# Altium schematic sheet p2_mcu.SchDoc(p2_mcu)
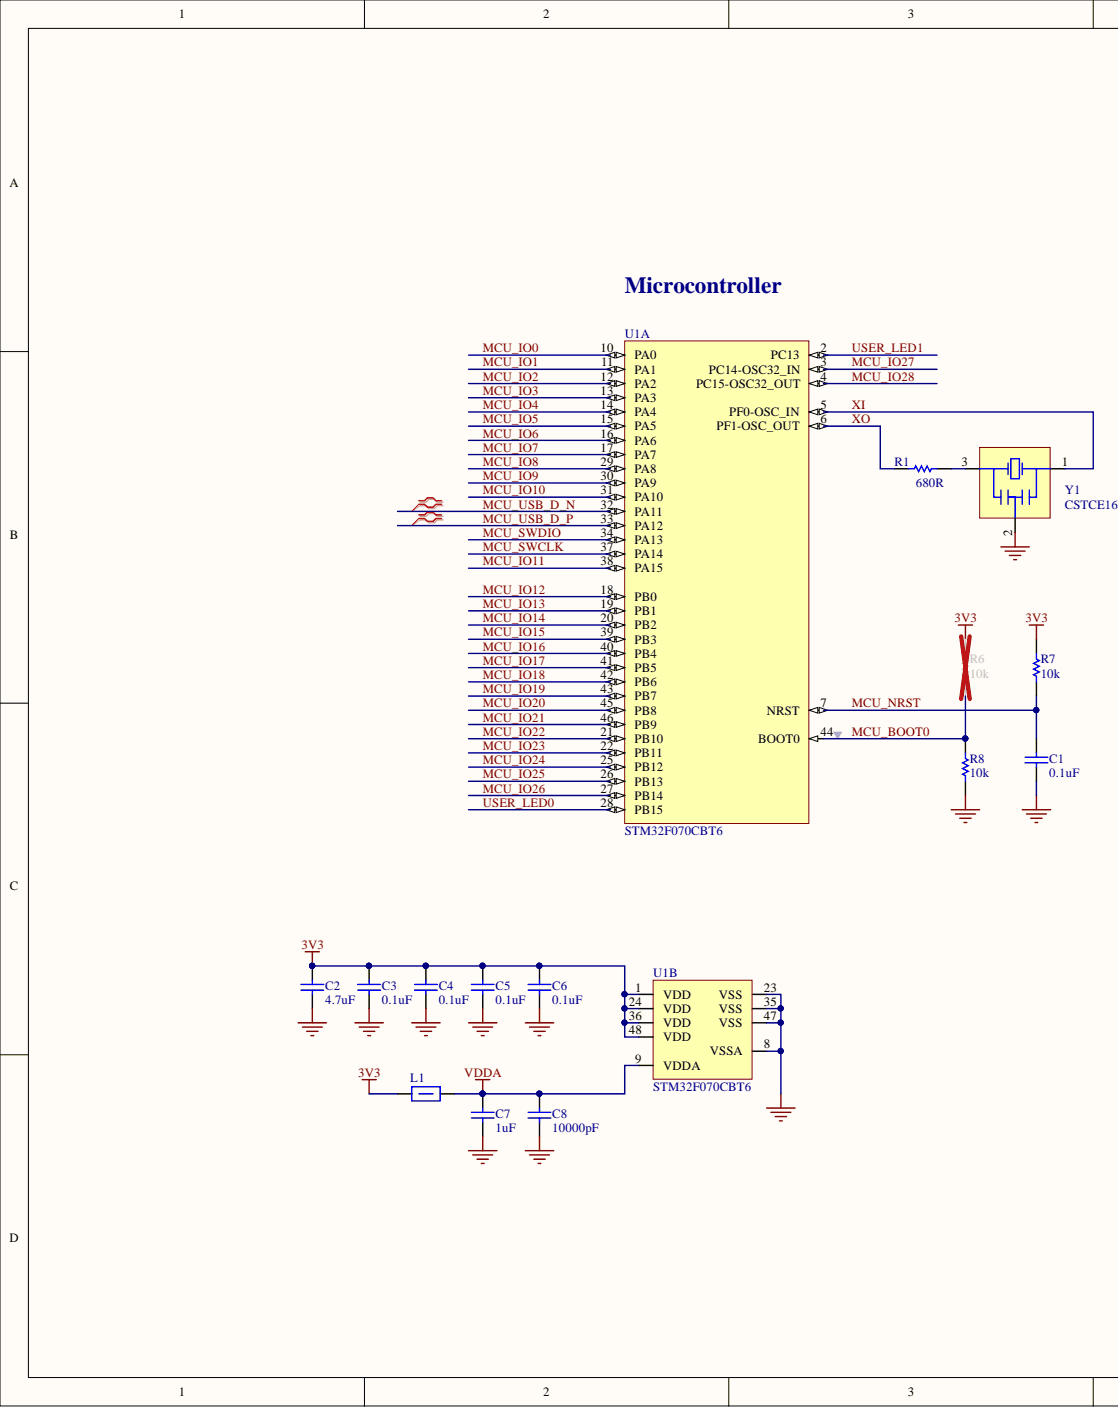
[diagram p0: p2_mcu.SchDoc, left]
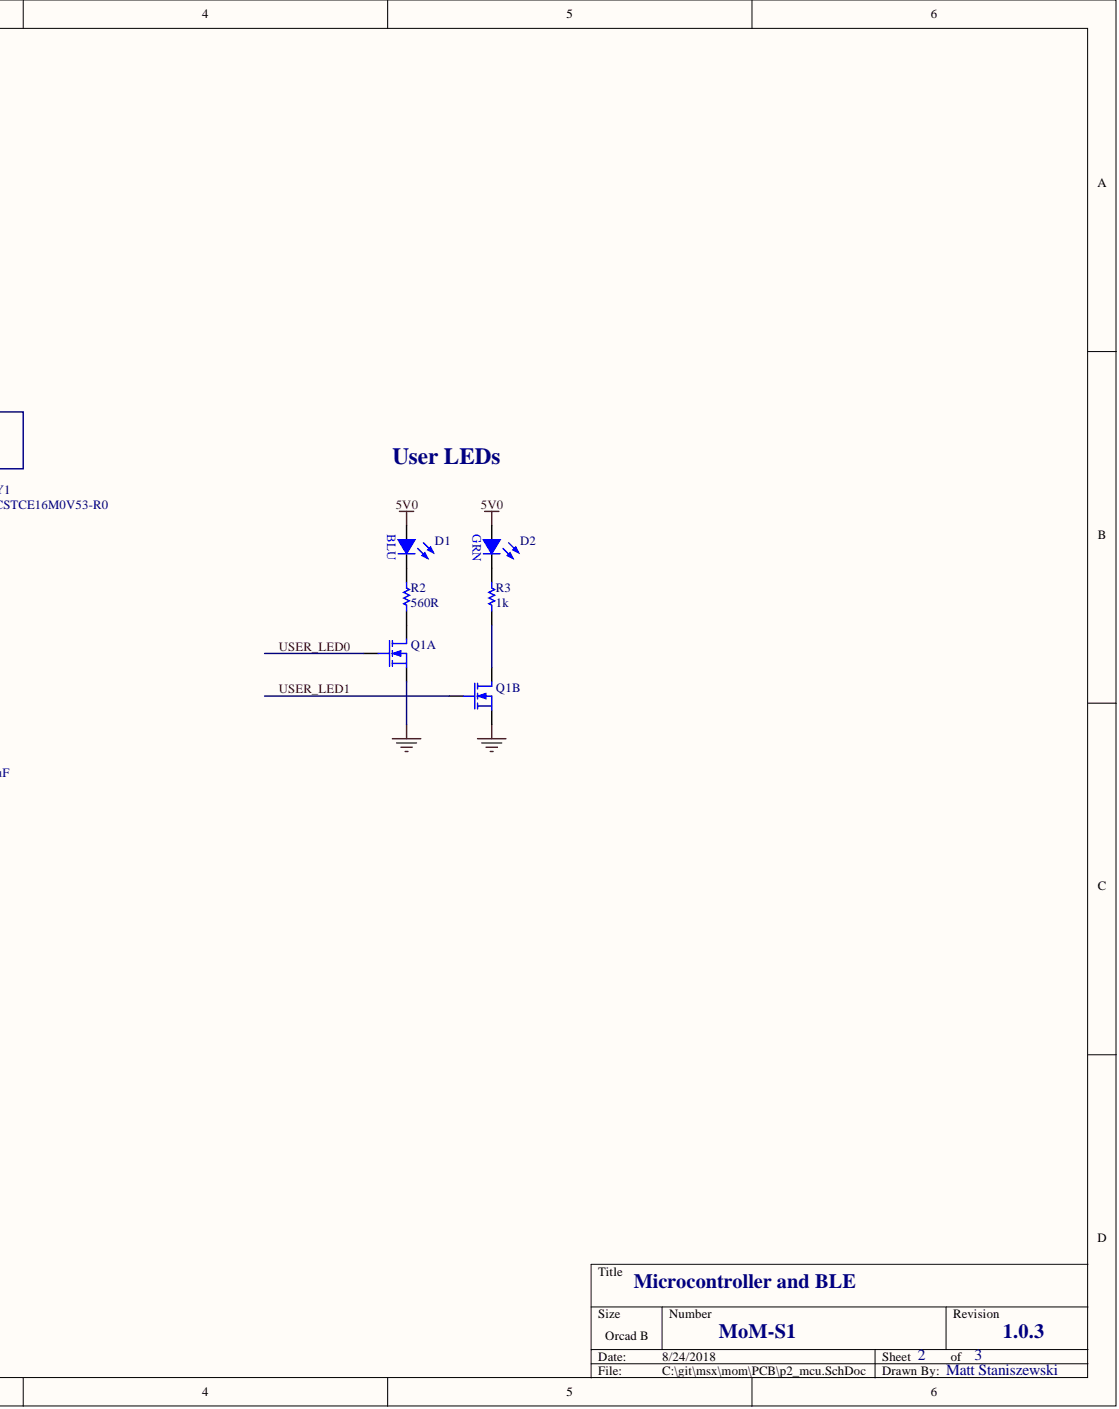
[diagram p1: p2_mcu.SchDoc, right]

## Parts
C1  0.1uF  [C0201]
C2  4.7uF  [C0402]
C3  0.1uF  [C0201]
C4  0.1uF  [C0201]
C5  0.1uF  [C0201]
C6  0.1uF  [C0201]
C7  1uF  [C0402]
C8  10000pF  [C0201]
D1  5988091107F  [LED0603]  (value not drawn)
D2  5988070107F  [LED0603]  (value not drawn)
L1  BLM15AG601SN1D  [L0402]  (value not drawn)
Q1  PMDXB600UNE  [NXP_DFN1010B-6]  (value not drawn)  (parts A,B)
R1  680R  [R0201]
R2  560R  [R0201]
R3  1k  [R0201]
R6  10k  [R0201]
R7  10k  [R0201]
R8  10k  [R0201]
U1  STM32F070CBT6  [STM_LQFP48]  (parts A,B)
Y1  CSTCE16M0V53-R0  [MUR_CSTCE_V]

## Nets
3V3: C2.2 C3.2 C4.2 C5.2 C6.2 L1.1 R6.2 R7.2 U1.1(VDD) U1.24(VDD) U1.36(VDD) U1.48(VDD)
5V0: D1.2 D2.2
GND: C1.1 C2.1 C3.1 C4.1 C5.1 C6.1 C7.1 C8.1 Q1.1 Q1.4 R8.1 U1.8(VSSA) U1.23(VSS) U1.35(VSS) U1.47(VSS) Y1.2
VDDA: C7.2 C8.2 L1.2 U1.9(VDDA)
MCU_BOOT0: R6.1 R8.2 U1.44(BOOT0)
MCU_IO0: U1.10(PA0)
MCU_IO1: U1.11(PA1)
MCU_IO2: U1.12(PA2)
MCU_IO3: U1.13(PA3)
MCU_IO4: U1.14(PA4)
MCU_IO5: U1.15(PA5)
MCU_IO6: U1.16(PA6)
MCU_IO7: U1.17(PA7)
MCU_IO8: U1.29(PA8)
MCU_IO9: U1.30(PA9)
MCU_IO10: U1.31(PA10)
MCU_IO11: U1.38(PA15)
MCU_IO12: U1.18(PB0)
MCU_IO13: U1.19(PB1)
MCU_IO14: U1.20(PB2)
MCU_IO15: U1.39(PB3)
MCU_IO16: U1.40(PB4)
MCU_IO17: U1.41(PB5)
MCU_IO18: U1.42(PB6)
MCU_IO19: U1.43(PB7)
MCU_IO20: U1.45(PB8)
MCU_IO21: U1.46(PB9)
MCU_IO22: U1.21(PB10)
MCU_IO23: U1.22(PB11)
MCU_IO24: U1.25(PB12)
MCU_IO25: U1.26(PB13)
MCU_IO26: U1.27(PB14)
MCU_IO27: U1.3(PC14-OSC32_IN)
MCU_IO28: U1.4(PC15-OSC32_OUT)
MCU_NRST: C1.2 R7.1 U1.7(NRST)
MCU_SWCLK: U1.37(PA14)
MCU_SWDIO: U1.34(PA13)
MCU_USB_D_N: U1.32(PA11)
MCU_USB_D_P: U1.33(PA12)
USER_LED0: Q1.2 U1.28(PB15)
USER_LED1: Q1.5 U1.2(PC13)
XI: U1.5(PF0-OSC_IN) Y1.1
XO: R1.1 U1.6(PF1-OSC_OUT)
NetD1_1: D1.1 R2.2
NetD2_1: D2.1 R3.2
NetQ1_3: Q1.3 R3.1
NetQ1_6: Q1.6 R2.1
NetR1_2: R1.2 Y1.3

## Library data of the parts
C1 C3-C6 = 0.1uF 16V 0201: CAP CER 0.1UF 16V X5R 0201; Manufacturer Part Number: GRM033R61C104ME84D; Manufacturer: Murata; Tolerance: 20%; Capacitance: 1E-07f; Width: 0.3mm; Weight: 1.2E-05oz; Voltage Rating (DC): 16V; Voltage Rating: 16V; Thickness: 0.013inch; Termination: SMD/SMT; Supplier Part Number 1: 490-9986-1-ND; Supplier 1: Digi-Key; Stock 1: 660567; Pricing 1: 1=0.1, 10=0.029, 100=0.013, 500=0.0093, 1000=0.0073, 2500=0.0067, 5000=0.0061 (USD); Packaging: Tape and Reel; Package Quantity: 15000; Min Operating Temperature: -55°C; Max Operating Temperature: 85°C; Library Name: Capacitors.SchLib; Length: 0.024inch; Height: 0.3mm; Dielectric: X5R; ComponentLink1URL: http://www.mouser.com/ds/2/281/c02e-2905.pdf; ComponentLink1Description: http://www.mouser.com/ds/2/281/c02e-2905.pdf; Component Kind: Standard; Case Code (Metric): 0603; Case Code (Imperial): 0201; Case/Package: 0201
C2 = 4.7uF 16V 0402: CAP CER 4.7UF 16V X5R 0402; Manufacturer Part Number: CL05A475MO5NUNC; Manufacturer: Samsung; Tolerance: 20%; Width: 0.02inch; Voltage Rating: 16V; Thickness: 0.022inch; Supplier Part Number 1: 1276-6836-1-ND; Supplier 1: Digi-Key; Stock 1: 282710; Pricing 1: 1=0.36, 10=0.248, 100=0.1485, 500=0.1114, 1000=0.0941, 2500=0.0891, 5000=0.0842 (USD); Packaging: Tape and Reel; Min Operating Temperature: -55°C; Max Operating Temperature: 85°C; Library Name: Capacitors.SchLib; Length: 0.039inch; Dielectric: X5R; Component Kind: Standard; Case Code (Metric): 1005; Case Code (Imperial): 0402; Case/Package: 0402; Capacitance: 4.7uF
C7 = 1uF 25V 0402: CAP CER 1UF 25V X5R 0402; Manufacturer Part Number: TMK105BJ105MV-F; Manufacturer: Taiyo Yuden; Tolerance: 20%; Width: 0.02inch; Voltage Rating (DC): 25V; Voltage Rating: 25V; Thickness: 0.022inch; Termination: SMD/SMT; Supplier Part Number 1: 587-3245-1-ND; Supplier 1: Digi-Key; Stock 1: 113342; Radiation Hardening: No; Pricing 1: 1=0.2, 10=0.14, 100=0.066, 500=0.0463, 1000=0.0383, 2500=0.0357, 5000=0.0326 (USD); Packaging: Tape and Reel; Package Quantity: 1; Mount: Surface Mount; Min Operating Temperature: -55°C; Max Operating Temperature: 85°C; Library Name: Capacitors.SchLib; Length: 1mm; Height: 0.5mm; Halogen Free: Halogen Free; Dielectric: X5R; Depth: 0.5mm; Composition: Ceramic; ComponentLink4URL: https://datasheet.ciiva.com/30154/128tmk105bj105mv-f-30154639.pdf; ComponentLink4Description: https://datasheet.ciiva.com/30154/128tmk105bj105mv-f-30154639.pdf; ComponentLink3URL: https://datasheet.ciiva.com/13404/mlcc-all-e-541559-13404206.pdf; ComponentLink3Description: https://datasheet.ciiva.com/13404/mlcc-all-e-541559-13404206.pdf; ComponentLink2URL: https://datasheet.ciiva.com/15922/tmk105bj105mv-f-pdf-15922317.pdf; ComponentLink2Description: https://datasheet.ciiva.com/15922/tmk105bj105mv-f-pdf-15922317.pdf; ComponentLink1URL: https://datasheet.ciiva.com/11596/tmk105bj105mv-f-ss-11596466.pdf; ComponentLink1Description: https://datasheet.ciiva.com/11596/tmk105bj105mv-f-ss-11596466.pdf; Component Kind: Standard; Case Code (Metric): 1005; Case Code (Imperial): 0402; Case/Package: 0402; Capacitance: 1uF
C8 = 10000pF 25V 0201: CAP CER 10000PF 25V X5R 0201; Manufacturer Part Number: GRM033R61E103MA12D; Manufacturer: Murata; Tolerance: 20%; Capacitance: 10nF; Width: 0.012inch; Voltage Rating (DC): 25V; Voltage Rating: 25V; Thickness: 0.013inch; Supplier Part Number 1: 490-9992-1-ND; Supplier 1: Digi-Key; Stock 1: 20535; Pricing 1: 1=0.1, 10=0.024, 100=0.0106, 500=0.00756, 1000=0.00595, 2500=0.00541, 5000=0.00497 (USD); Packaging: Tape and Reel; Mount: Surface Mount; Min Operating Temperature: -55°C; Max Operating Temperature: 85°C; Library Name: Capacitors.SchLib; Length: 0.024inch; Dielectric: X5R; ComponentLink1URL: http://search.murata.co.jp/Ceramy/image/img/A01X/partnumbering_e_01.pdf; ComponentLink1Description: http://search.murata.co.jp/Ceramy/image/img/A01X/partnumbering_e_01.pdf; Component Kind: Standard; Case Code (Metric): 0603; Case Code (Imperial): 0201; Case/Package: 0201
D1 = Blue 0603 LED: LED BLUE CLEAR 0603 SMD; Manufacturer Part Number: 598-8091-107F; Manufacturer: Dialight; Wavelength: 475nm; Viewing Angle: 140°; Test Current: 20mA; Power Dissipation: 70mW; Number of LEDs: 1; Luminous Intensity: 140mcd; Lens Style: Clear,Diffused,Colorless,Rectangular; Lens Colour: Clear,Colorless; Forward Voltage: 3.2V; Dominant Wavelength: 470nm; Width: 0.8mm; Supplier Part Number 1: 350-2037-1-ND; Supplier 1: Digi-Key; Stock 1: 42042; REACH SVHC: No SVHC; Radiation Hardening: No; Pricing 1: 1=0.75, 10=0.583, 25=0.4864, 100=0.4189, 250=0.374, 500=0.3216, 1000=0.2483 (USD); Packaging: Tape and Reel; Package Quantity: 4000; Number of Pins: 2; Number of Elements: 1; Mount: Surface Mount; Min Operating Temperature: -30°C; Max Operating Temperature: 100°C; Library Name: Diodes.SchLib; Lens Transparency: Clear; Length: 1.6mm; Lead Free: Lead Free; Illumination Colour: Blue; Height: 0.7mm; Forward Current: 20mA; Depth: 0.8mm; ComponentLink9URL: https://datasheet.ciiva.com/16400/smt-selector-guide-16400478.pdf; ComponentLink9Description: https://datasheet.ciiva.com/16400/smt-selector-guide-16400478.pdf; ComponentLink8URL: https://datasheet.ciiva.com/14603/70081992-14603963.pdf; ComponentLink8Description: https://datasheet.ciiva.com/14603/70081992-14603963.pdf; ComponentLink7URL: https://datasheet.ciiva.com/7970/25658-7970182.pdf; ComponentLink7Description: https://datasheet.ciiva.com/7970/25658-7970182.pdf; ComponentLink6URL: https://datasheet.ciiva.com/2111/598-series-0603-pkg-2111558.pdf; ComponentLink6Description: https://datasheet.ciiva.com/2111/598-series-0603-pkg-2111558.pdf; ComponentLink5URL: https://datasheet.ciiva.com/30240/mdei5980603-30240533.pdf; ComponentLink5Description: https://datasheet.ciiva.com/30240/mdei5980603-30240533.pdf; ComponentLink4URL: https://datasheet.ciiva.com/15965/mdei5980603-15965445.pdf; ComponentLink4Description: https://datasheet.ciiva.com/15965/mdei5980603-15965445.pdf; ComponentLink3URL: https://datasheet.ciiva.com/2111/598-series-0603-pkg-2111557.pdf; ComponentLink3Description: https://datasheet.ciiva.com/2111/598-series-0603-pkg-2111557.pdf; ComponentLink2URL: https://datasheet.ciiva.com/2111/598-series-0603-pkg-2111556.pdf; ComponentLink2Description: https://datasheet.ciiva.com/2111/598-series-0603-pkg-2111556.pdf; ComponentLink1URL: https://datasheet.ciiva.com/15118/getdatasheetpartid-194139-15118048.pdf; ComponentLink1Description: https://datasheet.ciiva.com/15118/getdatasheetpartid-194139-15118048.pdf; Component Kind: Standard; Colour: Blue; Case Code (Metric): 1608; Case Code (Imperial): 0603; Case/Package: 0603
D2 = Green 0603 LED: LED GREEN CLEAR 0603 SMD; Manufacturer Part Number: 598-8070-107F; Manufacturer: Dialight; Wavelength: 570nm; Viewing Angle: 140°; Test Current: 20mA; Power Dissipation: 60mW; Number of LEDs: 1; Luminous Intensity: 20mcd; Lens Style: Clear,Diffused,Colorless,Rectangular; Forward Voltage: 2V; Dominant Wavelength: 568nm; Width: 0.8mm; Supplier Part Number 1: 350-2035-1-ND; Supplier 1: Digi-Key; Stock 1: 147636; REACH SVHC: No SVHC; Radiation Hardening: No; Pricing 1: 1=0.45, 10=0.327, 25=0.2504, 100=0.1634, 250=0.1198, 500=0.1016, 1000=0.0835 (USD); Packaging: Tape and Reel; Package Quantity: 4000; Number of Pins: 2; Number of Elements: 1; Mount: Surface Mount; Min Operating Temperature: -30°C; Max Operating Temperature: 100°C; Library Name: Diodes.SchLib; Lens Colour: Clear; Length: 1.6mm; Lead Free: Lead Free; Illumination Colour: Green; Height: 0.7mm; Forward Current: 20mA; Depth: 0.8mm; ComponentLink13URL: https://datasheet.ciiva.com/16400/smt-selector-guide-16400463.pdf; ComponentLink13Description: https://datasheet.ciiva.com/16400/smt-selector-guide-16400463.pdf; ComponentLink12URL: https://datasheet.ciiva.com/22749/1484480-22749228.pdf; ComponentLink12Description: https://datasheet.ciiva.com/22749/1484480-22749228.pdf; ComponentLink11URL: https://datasheet.ciiva.com/15117/getdatasheetpartid-146438-15117836.pdf; ComponentLink11Description: https://datasheet.ciiva.com/15117/getdatasheetpartid-146438-15117836.pdf; ComponentLink10URL: https://datasheet.ciiva.com/30188/mdei5980603-30188139.pdf; ComponentLink10Description: https://datasheet.ciiva.com/30188/mdei5980603-30188139.pdf; ComponentLink9URL: https://datasheet.ciiva.com/15965/mdei5980603-15965419.pdf; ComponentLink9Description: https://datasheet.ciiva.com/15965/mdei5980603-15965419.pdf; ComponentLink8URL: https://datasheet.ciiva.com/14605/70081990-14605530.pdf; ComponentLink8Description: https://datasheet.ciiva.com/14605/70081990-14605530.pdf; ComponentLink7URL: https://datasheet.ciiva.com/2110/598-series-0603-pkg-2110167.pdf; ComponentLink7Description: https://datasheet.ciiva.com/2110/598-series-0603-pkg-2110167.pdf; ComponentLink6URL: https://datasheet.ciiva.com/2110/598-series-0603-pkg-2110166.pdf; ComponentLink6Description: https://datasheet.ciiva.com/2110/598-series-0603-pkg-2110166.pdf; ComponentLink5URL: https://datasheet.ciiva.com/1243/1484480-1243894.pdf; ComponentLink5Description: https://datasheet.ciiva.com/1243/1484480-1243894.pdf; ComponentLink4URL: https://datasheet.ciiva.com/2110/598-series-0603-pkg-2110165.pdf; ComponentLink4Description: https://datasheet.ciiva.com/2110/598-series-0603-pkg-2110165.pdf; ComponentLink3URL: https://datasheet.ciiva.com/1243/1484480-1243893.pdf; ComponentLink3Description: https://datasheet.ciiva.com/1243/1484480-1243893.pdf; ComponentLink2URL: https://datasheet.ciiva.com/11774/0900766b80ae2975-11774257.pdf; ComponentLink2Description: https://datasheet.ciiva.com/11774/0900766b80ae2975-11774257.pdf; ComponentLink1URL: https://datasheet.ciiva.com/4688/598-selectorguide-18902-4688880.pdf; ComponentLink1Description: https://datasheet.ciiva.com/4688/598-selectorguide-18902-4688880.pdf; Component Kind: Standard; Colour: Green; Case Code (Metric): 1608; Case Code (Imperial): 0603; Case/Package: 0603
L1 = BLM15AG601SN1D: FERRITE BEAD 600 OHM 0402 1LN; Manufacturer: Murata; Tolerance: 25%; Test Frequency: 100MHz; Shielding: Unshielded; Number of Lines: 1; Max DC Current: 300mA; Material: Steel,Ferrite; Impedance: 600R; DC Resistance (DCR): 520mR; Width: 0.5mm; Termination: SMD/SMT; Supplier Part Number 1: 490-1006-1-ND; Supplier 1: Digi-Key; Stock 1: 1368913; REACH SVHC: No SVHC; Radiation Hardening: No; Pricing 1: 1=0.1, 10=0.069, 25=0.0628, 50=0.0564, 100=0.0414, 250=0.03008, 500=0.02758, 1000=0.02383, 5000=0.01881 (USD); Packaging: Tape and Reel; Package Quantity: 10000; Number of Pins: 2; Number of Circuits: 1; Min Operating Temperature: -55°C; Military Standard: Not; Max Operating Temperature: 125°C; Max Current Rating: 300mA; Manufacturer Part Number: BLM15AG601SN1D; Library Name: Inductors.SchLib; Length: 1mm; Lead Free: Lead Free; Height: 0.5mm; Frequency: 100MHz; Depth: 0.55mm; DC Current: 300mA; Current Rating: 300mA; Core Material: Ferrite; ComponentLink11URL: https://datasheet.ciiva.com/41449/001172163-da-01-en-drossel-0402-600r-1-0x0-5mm-10000st-41449450.pdf; ComponentLink11Description: https://datasheet.ciiva.com/41449/001172163-da-01-en-drossel-0402-600r-1-0x0-5mm-10000st-41449450.pdf; ComponentLink10URL: https://datasheet.ciiva.com/29347/442210-da-01-en-chip-drossel-0402-600r-300ma-1-0x0-5mm-29347879.pdf; ComponentLink10Description: https://datasheet.ciiva.com/29347/442210-da-01-en-chip-drossel-0402-600r-300ma-1-0x0-5mm-29347879.pdf; ComponentLink9URL: https://datasheet.ciiva.com/8084/l0110s0100blm15ag-sn-8084271.pdf; ComponentLink9Description: https://datasheet.ciiva.com/8084/l0110s0100blm15ag-sn-8084271.pdf; ComponentLink8URL: https://datasheet.ciiva.com/14122/blm-series-eng-tds-14122894.pdf; ComponentLink8Description: https://datasheet.ciiva.com/14122/blm-series-eng-tds-14122894.pdf; ComponentLink7URL: https://datasheet.ciiva.com/8510/l0110s0100blm15ag-sn-8510909.pdf; ComponentLink7Description: https://datasheet.ciiva.com/8510/l0110s0100blm15ag-sn-8510909.pdf; ComponentLink6URL: https://datasheet.ciiva.com/11799/0900766b811b5866-11799226.pdf; ComponentLink6Description: https://datasheet.ciiva.com/11799/0900766b811b5866-11799226.pdf; ComponentLink5URL: https://datasheet.ciiva.com/1466/5144-1466745.pdf; ComponentLink5Description: https://datasheet.ciiva.com/1466/5144-1466745.pdf; ComponentLink4URL: https://datasheet.ciiva.com/6212/l0110s0100blm15ag-sn-6212520.pdf; ComponentLink4Description: https://datasheet.ciiva.com/6212/l0110s0100blm15ag-sn-6212520.pdf; ComponentLink3URL: https://datasheet.ciiva.com/1466/5144-1466744.pdf; ComponentLink3Description: https://datasheet.ciiva.com/1466/5144-1466744.pdf; ComponentLink2URL: https://datasheet.ciiva.com/6212/l0110s0100blm15ag-sn-6212519.pdf; ComponentLink2Description: https://datasheet.ciiva.com/6212/l0110s0100blm15ag-sn-6212519.pdf; ComponentLink1URL: https://datasheet.ciiva.com/6212/l0110s0100blm15ag-sn-6212565.pdf; ComponentLink1Description: https://datasheet.ciiva.com/6212/l0110s0100blm15ag-sn-6212565.pdf; Component Kind: Standard; Case Code (Metric): 1005; Case Code (Imperial): 0402; Case/Package: 0402
Q1 = PMDXB600UNE: MOSFET 2N-CH 20V 0.6A 6DFN; Manufacturer: NXP Semiconductors; Threshold Voltage: 700mV; Rds On Max: 620mR; Max Power Dissipation: 265mW; Input Capacitance: 21.3pF; Continuous Drain Current (ID): 600mA; Supplier Part Number 1: 1727-1470-1-ND; Supplier 1: Digi-Key; Stock 1: 27879; REACH SVHC: No SVHC; Pricing 1: 1=0.65, 10=0.552, 100=0.4124, 500=0.324, 1000=0.2504 (USD); Packaging: Tape and Reel; Number of Pins: 6; Min Operating Temperature: -55°C; Max Operating Temperature: 150°C; Manufacturer Part Number: PMDXB600UNE; Library Name: ICs.SchLib; Drain to Source Voltage (Vdss): 20V; ComponentLink3URL: https://datasheet.ciiva.com/29589/pmdxb600une-29589933.pdf; ComponentLink3Description: https://datasheet.ciiva.com/29589/pmdxb600une-29589933.pdf; ComponentLink2URL: https://datasheet.ciiva.com/29589/pmdxb600une-29589932.pdf; ComponentLink2Description: https://datasheet.ciiva.com/29589/pmdxb600une-29589932.pdf; ComponentLink1URL: https://datasheet.ciiva.com/29589/pmdxb600une-29589931.pdf; ComponentLink1Description: https://datasheet.ciiva.com/29589/pmdxb600une-29589931.pdf; Component Kind: Standard; Case/Package: 600
R1 = 680R 0201: RES SMD 680 OHM 1% 1/20W 0201; Manufacturer Part Number: RC0201FR-07680RL; Manufacturer: Yageo; Voltage Rating: 25V; Temperature Coefficient: 200ppm/°C; Power Rating: 50mW; Width: 0.012inch; Tolerance: 1%; Termination: SMD/SMT; Supplier Part Number 1: YAG2769CT-ND; Supplier 1: Digi-Key; Stock 1: 13371; Resistance: 680R; REACH SVHC: No SVHC; Pricing 1: 1=0.1, 10=0.046, 100=0.0187, 1000=0.00841, 2500=0.0073, 5000=0.00603 (USD); Packaging: Tape and Reel; Number of Terminations: 2; Min Operating Temperature: -55°C; Military Standard: Not; Max Power Dissipation: 50mW; Max Operating Temperature: 125°C; Library Name: Resistors.SchLib; Length: 0.6mm; Lead Free: Lead Free; Height: 0.01inch; Depth: 0.3mm; Composition: Thick Film; ComponentLink1URL: https://datasheet.ciiva.com/7672/1563626-7672238.pdf; ComponentLink1Description: https://datasheet.ciiva.com/7672/1563626-7672238.pdf; Component Kind: Standard; Case Code (Metric): 0603; Case Code (Imperial): 0201; Case/Package: 0201
R2 = 560R 0201: RES SMD 560 OHM 1% 1/20W 0201; Manufacturer Part Number: ERJ-1GEF5600C; Manufacturer: Panasonic ECG; Voltage Rating (DC): 15V; Voltage Rating: 25V; Temperature Coefficient: 200ppm/°C; Power Rating: 50mW; Width: 0.3mm; Tolerance: 1%; Supplier Part Number 1: P560ABCT-ND; Supplier 1: Digi-Key; Stock 1: 11988; Resistance: 560R; Radiation Hardening: No; Pricing 1: 1=0.1, 10=0.059, 100=0.024, 1000=0.0108, 2500=0.0094, 5000=0.0077 (USD); Packaging: Tape and Reel; Package Quantity: 15000; Number of Terminations: 2; Mount: Surface Mount; Min Operating Temperature: -55°C; Military Standard: Not; Max Power Dissipation: 50mW; Max Operating Temperature: 125°C; Library Name: Resistors.SchLib; Length: 0.6mm; Lead Free: Lead Free; Height: 0.01inch; Depth: 0.3mm; Contact Plating: Tin; Composition: Thick Film; ComponentLink3URL: https://datasheet.ciiva.com/2440/erj-g-r-e-rev08-2440590.pdf; ComponentLink3Description: https://datasheet.ciiva.com/2440/erj-g-r-e-rev08-2440590.pdf; ComponentLink2URL: https://datasheet.ciiva.com/2440/erj-g-r-e-rev08-2440589.pdf; ComponentLink2Description: https://datasheet.ciiva.com/2440/erj-g-r-e-rev08-2440589.pdf; ComponentLink1URL: https://datasheet.ciiva.com/2440/erj-g-r-e-rev08-2440588.pdf; ComponentLink1Description: https://datasheet.ciiva.com/2440/erj-g-r-e-rev08-2440588.pdf; Component Kind: Standard; Case Code (Metric): 0603; Case Code (Imperial): 0201; Case/Package: 0201
R3 = 1k 0201: RES SMD 1K OHM 1% 1/20W 0201; Manufacturer Part Number: ERJ-1GEF1001C; Manufacturer: Panasonic ECG; Voltage Rating (DC): 15V; Voltage Rating: 25V; Temperature Coefficient: 200ppm/°C; Resistance: 1KR; Power Rating: 50mW; Width: 0.012inch; Tolerance: 1%; Termination: SMD/SMT; Supplier Part Number 1: P1.00KABCT-ND; Supplier 1: Digi-Key; Stock 1: 3138057; REACH SVHC: Unknown; Radiation Hardening: No; Pricing 1: 1=0.1, 10=0.059, 100=0.024, 1000=0.0108, 2500=0.0094, 5000=0.0077 (USD); Packaging: Tape and Reel; Package Quantity: 15000; Number of Terminations: 2; Mount: Surface Mount; Min Operating Temperature: -55°C; Military Standard: Not; Max Power Dissipation: 50mW; Max Operating Temperature: 125°C; Library Name: Resistors.SchLib; Length: 0.6mm; Lead Free: Lead Free; Height: 0.23mm; Depth: 0.3mm; Contact Plating: Tin; Composition: Thick Film; ComponentLink6URL: https://datasheet.ciiva.com/16782/70260683-16782463.pdf; ComponentLink6Description: https://datasheet.ciiva.com/16782/70260683-16782463.pdf; ComponentLink5URL: https://datasheet.ciiva.com/7942/1678796-7942463.pdf; ComponentLink5Description: https://datasheet.ciiva.com/7942/1678796-7942463.pdf; ComponentLink4URL: https://datasheet.ciiva.com/30155/aoa0000ce2-30155870.pdf; ComponentLink4Description: https://datasheet.ciiva.com/30155/aoa0000ce2-30155870.pdf; ComponentLink3URL: https://datasheet.ciiva.com/16199/erj-1gef1001c-16199565.pdf; ComponentLink3Description: https://datasheet.ciiva.com/16199/erj-1gef1001c-16199565.pdf; ComponentLink2URL: https://datasheet.ciiva.com/16763/70260683-16763516.pdf; ComponentLink2Description: https://datasheet.ciiva.com/16763/70260683-16763516.pdf; ComponentLink1URL: https://datasheet.ciiva.com/28532/1694929-28532042.pdf; ComponentLink1Description: https://datasheet.ciiva.com/28532/1694929-28532042.pdf; Component Kind: Standard; Case Code (Metric): 0603; Case Code (Imperial): 0201; Case/Package: 0201
R6-R8 = 10k 0201: RES SMD 10K OHM 1% 1/20W 0201; Manufacturer Part Number: ERJ-1GEF1002C; Manufacturer: Panasonic ECG; Voltage Rating (DC): 15V; Voltage Rating: 25V; Temperature Coefficient: 200ppm/°C; Resistance: 10KR; Power Rating: 50mW; Width: 0.012inch; Tolerance: 1%; Termination: SMD/SMT; Supplier Part Number 1: P10.0KABCT-ND; Supplier 1: Digi-Key; Stock 1: 4709989; REACH SVHC: Unknown; Radiation Hardening: No; Pricing 1: 1=0.1, 10=0.059, 100=0.024, 1000=0.0108, 2500=0.0094, 5000=0.0077 (USD); Packaging: Tape and Reel; Package Quantity: 15000; Number of Terminations: 2; Mount: Surface Mount; Min Operating Temperature: -55°C; Military Standard: Not; Max Power Dissipation: 50mW; Max Operating Temperature: 125°C; Library Name: Resistors.SchLib; Length: 0.6mm; Lead Free: Lead Free; Height: 0.23mm; Depth: 0.3mm; Contact Plating: Tin; Composition: Thick Film; ComponentLink6URL: https://datasheet.ciiva.com/16770/70260683-16770095.pdf; ComponentLink6Description: https://datasheet.ciiva.com/16770/70260683-16770095.pdf; ComponentLink5URL: https://datasheet.ciiva.com/7830/1678796-7830952.pdf; ComponentLink5Description: https://datasheet.ciiva.com/7830/1678796-7830952.pdf; ComponentLink4URL: https://datasheet.ciiva.com/22785/1678796-22785991.pdf; ComponentLink4Description: https://datasheet.ciiva.com/22785/1678796-22785991.pdf; ComponentLink3URL: https://datasheet.ciiva.com/16775/70260683-16775527.pdf; ComponentLink3Description: https://datasheet.ciiva.com/16775/70260683-16775527.pdf; ComponentLink2URL: https://datasheet.ciiva.com/30111/aoa0000ce2-30111653.pdf; ComponentLink2Description: https://datasheet.ciiva.com/30111/aoa0000ce2-30111653.pdf; ComponentLink1URL: https://datasheet.ciiva.com/16427/erj-1gef1002c-16427252.pdf; ComponentLink1Description: https://datasheet.ciiva.com/16427/erj-1gef1002c-16427252.pdf; Component Kind: Standard; Case Code (Metric): 0603; Case Code (Imperial): 0201; Case/Package: 0201
U1 = STM32F070CBT6: IC MCU 32BIT 128KB FLASH 48LQFP; Manufacturer: STMicroelectronics; Watchdog Timer: Yes; RAM Size: 16KB; Peripherals: POR,PWM,WDT,DMA; Oscillator Type: Internal; Operating Supply Voltage: 3.3V; Number of Timers/Counters: 8; Number of Programmable I/O: 37; Number of ADC Channels: 1; Min Supply Voltage: 2.4V; Max Supply Voltage: 3.6V; Max Power Dissipation: 364mW; Max Frequency: 48MHz; Interface: I2C,SPI,USB,UART,USART; Data Bus Width: 32b; Core Architecture: ARM; Weight: 0.006409oz; Supplier Part Number 1: 497-15099-ND; Supplier 1: Digi-Key; Stock 1: 10286; Pricing 1: 1=2.52, 10=2.268, 100=1.8231, 500=1.49782, 1000=1.24106 (USD); Packaging: Tray; Package Quantity: 250; Number of Pins: 48; Number of I/Os: 37; Mount: Surface Mount; Min Operating Temperature: -40°C; Memory Type: FLASH; Memory Size: 128KB; Max Operating Temperature: 85°C; Manufacturer Part Number: STM32F070CBT6; Library Name: ICs.SchLib; Lead Free: Lead Free; Frequency: 48MHz; ComponentLink4URL: http://www.mouser.com/ds/2/389/stm32f070c6-956182.pdf; ComponentLink4Description: http://www.mouser.com/ds/2/389/stm32f070c6-956182.pdf; ComponentLink3URL: http://www.st.com/content/ccc/resource/technical/document/datasheet/31/4f/10/5f/a5/32/46/42/DM00141386.pdf/files/DM00141386.pdf/jcr:content/translations/en.DM00141386.pdf; ComponentLink3Description: http://www.st.com/content/ccc/resource/technical/document/datasheet/31/4f/10/5f/a5/32/46/42/DM00141386.pdf/files/DM00141386.pdf/jcr:content/translations/en.DM00141386.pdf; ComponentLink2URL: http://www.st.com/st-web-ui/static/active/en/resource/technical/document/datasheet/DM00141386.pdf; ComponentLink2Description: http://www.st.com/st-web-ui/static/active/en/resource/technical/document/datasheet/DM00141386.pdf; ComponentLink1URL: http://www.farnell.com/datasheets/2281751.pdf; ComponentLink1Description: http://www.farnell.com/datasheets/2281751.pdf; Component Kind: Standard; Case/Package: LQFP
Y1 = 16MHz Resonator: CER RES 16.0000MHZ 15PF SMD; Manufacturer: Murata; Tolerance: 0.5%; Self Resonant Frequency: 16MHz; Impedance: 40R; Frequency Stability: 0.3%; Width: 0.051inch; Termination: SMD/SMT; Supplier Part Number 1: 490-1198-1-ND; Supplier 1: Digi-Key; Stock 1: 104178; REACH SVHC: No SVHC; Pricing 1: 1=0.5, 10=0.42, 50=0.3776, 100=0.3357, 500=0.3189, 1000=0.2686 (USD); Packaging: Tape and Reel; Package Quantity: 3000; Number of Pins: 3; Min Operating Temperature: -20°C; Max Operating Temperature: 80°C; Manufacturer Part Number: CSTCE16M0V53-R0; Load Capacitance: 15pF; Library Name: Oscillators.SchLib; Length: 0.126inch; Lead Free: Lead Free; Height: 0.039inch; Frequency Tolerance: 0.5%; Frequency: 16MHz; Contact Plating: Gold; Composition: Ceramic; ComponentLink7URL: https://datasheet.ciiva.com/16216/l0430e-16216847.pdf; ComponentLink7Description: https://datasheet.ciiva.com/16216/l0430e-16216847.pdf; ComponentLink6URL: https://datasheet.ciiva.com/14132/ceralock-eng-bro-14132735.pdf; ComponentLink6Description: https://datasheet.ciiva.com/14132/ceralock-eng-bro-14132735.pdf; ComponentLink5URL: https://datasheet.ciiva.com/884/p16e-884479.pdf; ComponentLink5Description: https://datasheet.ciiva.com/884/p16e-884479.pdf; ComponentLink4URL: https://datasheet.ciiva.com/884/p16e-884478.pdf; ComponentLink4Description: https://datasheet.ciiva.com/884/p16e-884478.pdf; ComponentLink3URL: https://datasheet.ciiva.com/1361/1506176-1361293.pdf; ComponentLink3Description: https://datasheet.ciiva.com/1361/1506176-1361293.pdf; ComponentLink2URL: https://datasheet.ciiva.com/884/p16e-884477.pdf; ComponentLink2Description: https://datasheet.ciiva.com/884/p16e-884477.pdf; ComponentLink1URL: https://datasheet.ciiva.com/18183/getdatasheetpartid-152969-18183272.pdf; ComponentLink1Description: https://datasheet.ciiva.com/18183/getdatasheetpartid-152969-18183272.pdf; Component Kind: Standard; Case/Package: SMD/SMT; Capacitance: 15pF
